# Altium schematic sheet WatchDog.SchDoc
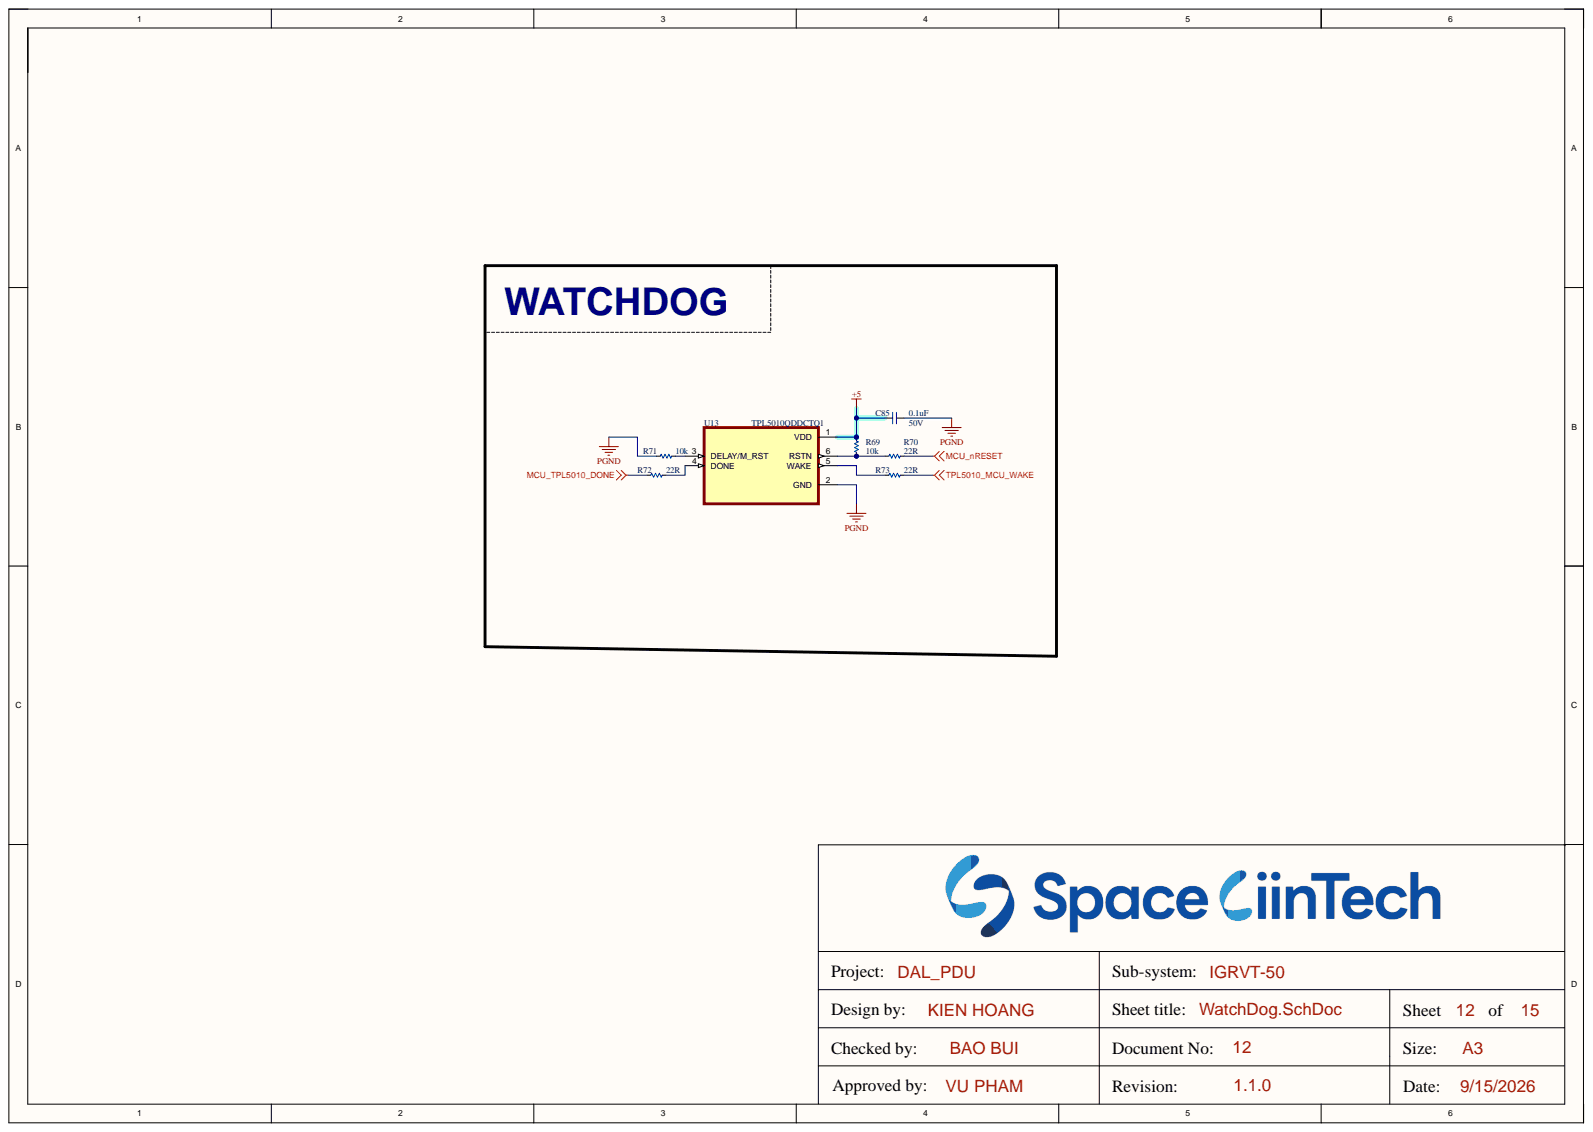
[diagram p0: WatchDog.SchDoc]

## Parts
C85  0.1uF  Voltage: 50V  [CAP_C_1608X80]
R69  10k  [RES_C_1608X60]
R70  22R  [RES_C_1608X60]
R71  10k  [RES_C_1608X60]
R72  22R  [RES_C_1608X60]
R73  22R  [RES_C_1608X60]
U13  TPL5010QDDCTQ1  [SOT-23-THN-6]

## Nets
+5: C85.2 R69.2 U13.1(VDD)
MCU_nRESET: R70.1
MCU_TPL5010_DONE: R72.2
PGND: C85.1 R71.1 U13.2(GND)
TPL5010_MCU_WAKE: R73.1
NetR69_1: R69.1 R70.2 U13.6(RSTN)
NetR71_2: R71.2 U13.3(DELAY/M_RST)
NetR72_1: R72.1 U13.4(DONE)
NetR73_2: R73.2 U13.5(WAKE)

## Library data of the parts
C85 = _CAP_C_2: CAP; Component Kind: Standard; Library Name: LABLIB.SchLib
R69-R73 = _RES_B_2_2: RES; Component Kind: Standard; Library Name: LABLIB.SchLib
U13 = TPL5010QDDCTQ1: Component Kind: Standard; Library Name: KIENASIY123_LIB.IntLib
